# Altium schematic sheet Melanson Tech - PWM Generator.SchDoc
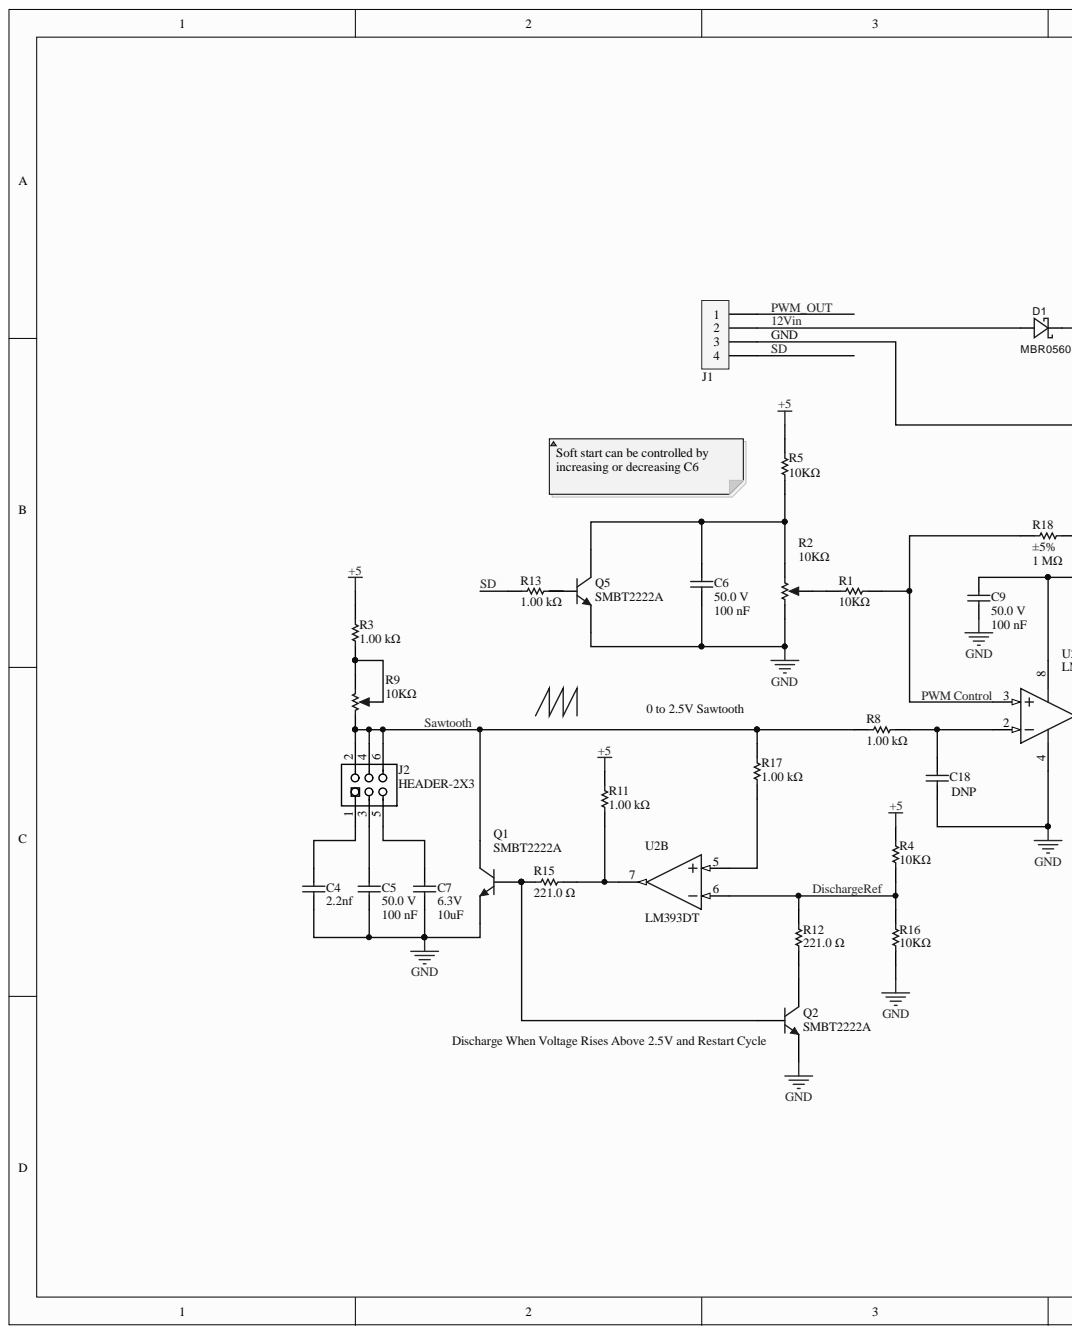
[diagram p0: Melanson Tech - PWM Generator.SchDoc, left]
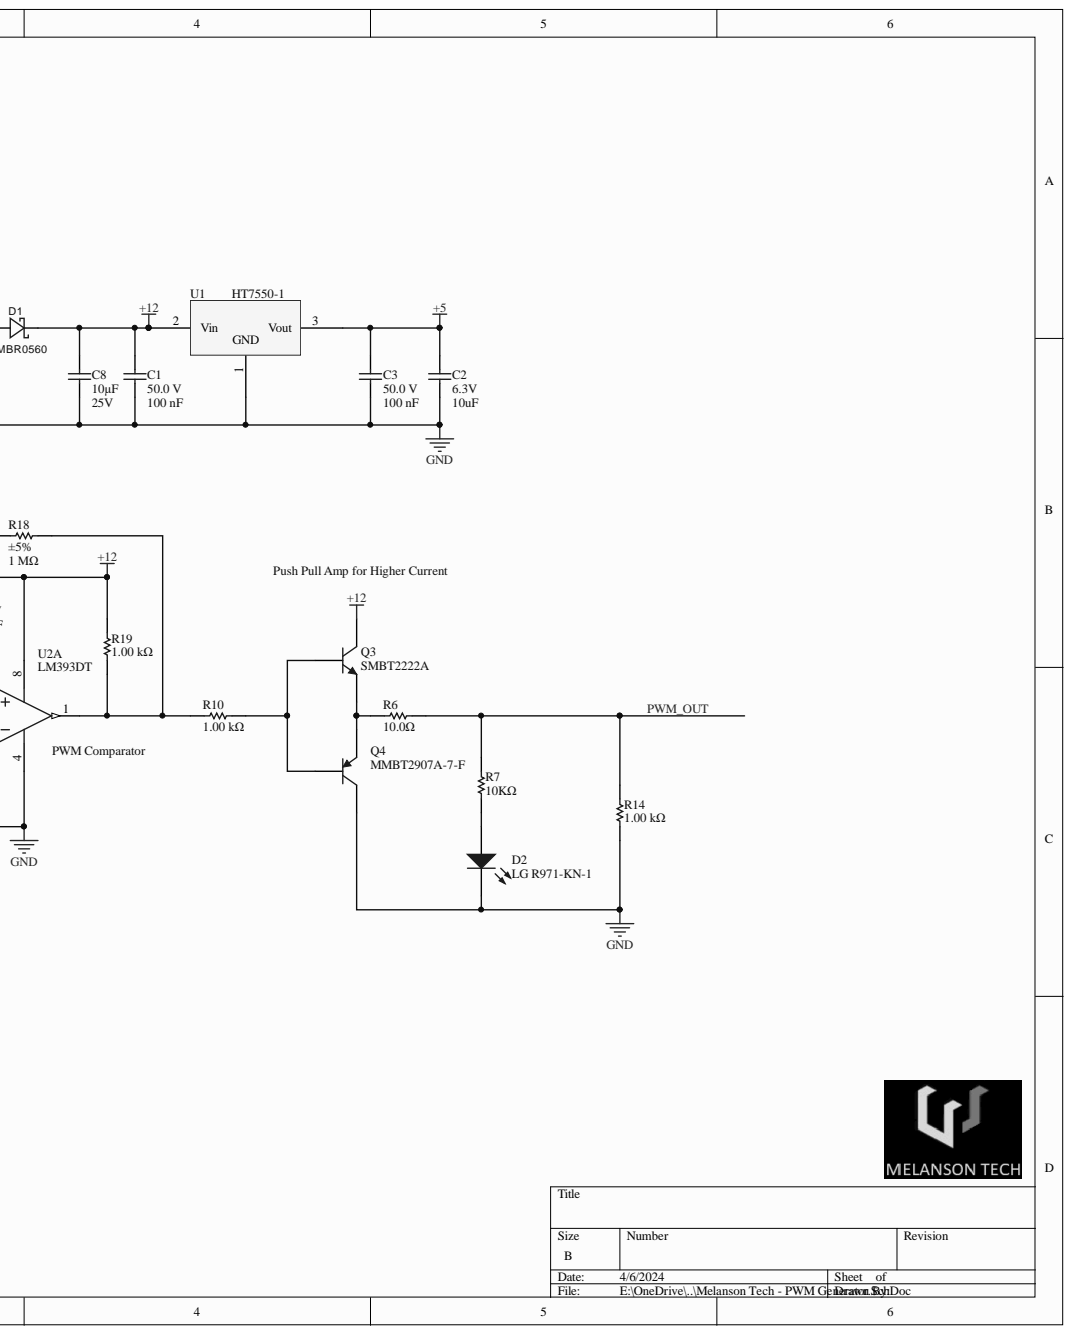
[diagram p1: Melanson Tech - PWM Generator.SchDoc, right]

## Parts
C1  08055C104JAT2A  [Cap,0805]  (value not drawn)
C2  Capacitance: 10uF  Voltage - Rated: 6.3V  [Cap,0805]  (hidden comment: CL21A106KQFNNNG)
C3  Voltage Rating (DC): 50.0 V  [Cap,0805]  (hidden comment: 08055C104JAT2A)
C4  2.2nf  [CAP, 0805, HD]
C5  08055C104JAT2A  [Cap,0805]  (value not drawn)
C6  Capacitance: 100 nF  Voltage Rating (DC): 50.0 V  [Cap,0805]  (hidden comment: 08055C104JAT2A)
C7  Capacitance: 10uF  Voltage - Rated: 6.3V  [Cap,0805]  (hidden comment: CL21A106KQFNNNG)
C8  Capacitance: 10µF  Voltage - Rated: 25V  [Cap, 1206]  (hidden comment: C3216X7R1E106K160AB)
C9  Capacitance: 100 nF  Voltage Rating (DC): 50.0 V  [Cap,0805]  (hidden comment: 08055C104JAT2A)
C18  Capacitance: DNP  [CAP, 0805, HD]  (hidden comment: GRM21A5C2E101JW01D)
D1  MBR0560  [SOD123]
D2  LG R971-KN-1  [LED,0805]
J1  Generic Header  [Header,4POS,0.1",Vert]  (value not drawn)
J2  HEADER-2X3  [HEADER-2X3]
Q1  SMBT2222A  [SOT23-3]
Q2  SMBT2222A  [SOT23-3]
Q3  SMBT2222A  [SOT23-3]
Q4  MMBT2907A-7-F  [SOT23-3]
Q5  SMBT2222A  [SOT23-3]
R1  Resistance: 10KΩ  [res, 0805, HD]  (hidden comment: Vishay / Dale CRCW080510K0FKTA)
R2  Resistance: 10KΩ  [Res,TH,POT,TopAdj]  (hidden comment: CT94EW103)
R3  Resistance: 1.00 kΩ  [res, 0805, HD]  (hidden comment: Vishay CRCW08051K00JNEA)
R4  Resistance: 10KΩ  [res, 0805, HD]  (hidden comment: Vishay / Dale CRCW080510K0FKTA)
R5  Resistance: 10KΩ  [res, 0805, HD]  (hidden comment: Vishay / Dale CRCW080510K0FKTA)
R6  Resistance: 10.0Ω  [res, 0805, HD]  (hidden comment: RMCF0805JT10R0)
R7  Resistance: 10KΩ  [res, 0805, HD]  (hidden comment: Vishay / Dale CRCW080510K0FKTA)
R8  Resistance: 1.00 kΩ  [res, 0805, HD]  (hidden comment: Vishay CRCW08051K00JNEA)
R9  Resistance: 10KΩ  [Res,TH,POT,TopAdj]  (hidden comment: CT94EW103)
R10  Resistance: 1.00 kΩ  [res, 0805, HD]  (hidden comment: Vishay CRCW08051K00JNEA)
R11  Resistance: 1.00 kΩ  [res, 0805, HD]  (hidden comment: Vishay CRCW08051K00JNEA)
R12  Vishay Dale CRCW0805221RFKTA  [res, 0805, HD]  (value not drawn)
R13  Resistance: 1.00 kΩ  [res, 0805, HD]  (hidden comment: Vishay CRCW08051K00JNEA)
R14  Resistance: 1.00 kΩ  [res, 0805, HD]  (hidden comment: Vishay CRCW08051K00JNEA)
R15  Resistance: 221.0 Ω  [res, 0805, HD]  (hidden comment: Vishay Dale CRCW0805221RFKTA)
R16  Resistance: 10KΩ  [res, 0805, HD]  (hidden comment: Vishay / Dale CRCW080510K0FKTA)
R17  Resistance: 1.00 kΩ  [res, 0805, HD]  (hidden comment: Vishay CRCW08051K00JNEA)
R18  Resistance: 1 MΩ  Tolerance: ±5%  [res, 0805, HD]  (hidden comment: CR0805-JW-105ELF)
R19  Resistance: 1.00 kΩ  [res, 0805, HD]  (hidden comment: Vishay CRCW08051K00JNEA)
U1  HT7550-1  [SOT89-3]
U2  LM393DT  [SOIC-8]  (parts A,B)

## Nets
+5: C2.2 C3.2 R3.2 R4.2 R5.2 R11.2 U1.3
+12: C1.2 C8.2 C9.2 D1.1 Q3.3 R19.1 U1.2 U2.8
GND: C1.1 C2.1 C3.1 C4.1 C5.1 C6.1 C7.1 C8.1 C9.1 C18.2 D2.2 J1.3 Q1.2 Q2.2 Q4.3 Q5.2 R2.3 R14.2 R16.1 U1.1 U2.4
12VIN: D1.2 J1.2
DISCHARGEREF: R4.1 R12.1 R16.2 U2.6
PWM CONTROL: R1.1 R18.2 U2.3
PWM_OUT: J1.1 R6.1 R7.2 R14.1
SAWTOOTH: J2.2 J2.4 J2.6 Q1.3 R8.1 R9.1 R17.2
SD: J1.4 R13.1
NetC4_2: C4.2 J2.1
NetC5_2: C5.2 J2.3
NetC6_2: C6.2 Q5.3 R2.1 R5.1
NetC7_2: C7.2 J2.5
NetC18_1: C18.1 R8.2 U2.2
NetD2_1: D2.1 R7.1
NetQ1_1: Q1.1 Q2.1 R15.2
NetQ2_3: Q2.3 R12.2
NetQ3_1: Q3.1 Q4.1 R10.2
NetQ3_2: Q3.2 Q4.2 R6.2
NetQ5_1: Q5.1 R13.2
NetR1_2: R1.2 R2.2
NetR3_1: R3.1 R9.2 R9.3
NetR10_1: R10.1 R18.1 R19.2 U2.1
NetR11_1: R11.1 R15.1 U2.7
NetR17_1: R17.1 U2.5

## Library data of the parts
C1 C5 = Cap,0805,100nf,50V,5%,08055C104JAT2A: Capacitor; Ceramic; Cap 0.1 uF; Tol 5%; Vol-Rtg 50 VDC; SMT; 0805; X7R; Tape & Reel; Capacitance: 100 nF; Case/Package (SI): 2012; Detailed Description: 0.1µF ±5% 50V Ceramic Capacitor X7R 0805 (2012 Metric); Insulation Resistance: 100 GΩ; Lead-Free Status: Lead Free; Voltage Rating (DC): 50.0 V; Capacitance Tolerance: ±5%; Case/Package: 0805; Component Kind: Standard; Dielectric Characteristic: X7R; digikey url: http://www.digikey.ca/product-detail/en/avx-corporation/08055C104JAT2A/478-3352-1-ND/930144; Library Name: Master JULY2019.SchLib; Manufacturer Part Number: 08055C104JAT2A; Mounting Style: Surface Mount; Number of Pins: 2; Octopart URL: http://octopart.com/08055c104jat2a-avx-55388010; Operating Temperature: -55°C ~ 125°C; Packaging: Tape & Reel (TR), Cut Tape (CT); REACH SVHC Compliance: No SVHC; RoHS: Compliant; Size-Height: 710 µm; Size-Length: 2.01 mm; Size-Thickness: 940 µm; Size-Width: 1.24 mm; Supplier 1: Digi-Key; Supplier Part Number 1: 478-3352-1-ND
C2 C7 = Cap,0805,10uf,6.3V,10%,CL21A106KQFNNNG: CL21 Series 10 uF 6.3V ±10 % Tolerance X5R SMT Multilayer Ceramic Capacitor; Lead-Free Status: Lead Free; Other Names: 1276-6457-2; Tolerance: ±10%; Applications: General Purpose; Component Kind: Standard; Library Name: Master JULY2019.SchLib; mfg P/N: CL21A106KQFNNNG; Mounting Style: Surface Mount; Operating Temperature: -55°C ~ 85°C; Package / Case: 0805 (2012 Metric); RoHS: Compliant; Supplier 1: Digi-Key; Supplier Part Number 1: 1276-6457-1-ND
C3 = Cap,0805,100nf,50V,5%,08055C104JAT2A: Capacitor; Ceramic; Cap 0.1 uF; Tol 5%; Vol-Rtg 50 VDC; SMT; 0805; X7R; Tape & Reel; Capacitance: 100 nF; Case/Package (SI): 2012; Detailed Description: 0.1µF ±5% 50V Ceramic Capacitor X7R 0805 (2012 Metric); Insulation Resistance: 100 GΩ; Lead-Free Status: Lead Free; Capacitance Tolerance: ±5%; Case/Package: 0805; Component Kind: Standard; Dielectric Characteristic: X7R; digikey url: http://www.digikey.ca/product-detail/en/avx-corporation/08055C104JAT2A/478-3352-1-ND/930144; Library Name: Master JULY2019.SchLib; Manufacturer Part Number: 08055C104JAT2A; Mounting Style: Surface Mount; Number of Pins: 2; Octopart URL: http://octopart.com/08055c104jat2a-avx-55388010; Operating Temperature: -55°C ~ 125°C; Packaging: Tape & Reel (TR), Cut Tape (CT); REACH SVHC Compliance: No SVHC; RoHS: Compliant; Size-Height: 710 µm; Size-Length: 2.01 mm; Size-Thickness: 940 µm; Size-Width: 1.24 mm; Supplier 1: Digi-Key; Supplier Part Number 1: 478-3352-1-ND
C4 = CAP,0805,Generic: Component Kind: Standard; Library Name: Master Nov2021.SchLib
C6 C9 = Cap,0805,100nf,50V,5%,08055C104JAT2A: Capacitor; Ceramic; Cap 0.1 uF; Tol 5%; Vol-Rtg 50 VDC; SMT; 0805; X7R; Tape & Reel; Case/Package (SI): 2012; Detailed Description: 0.1µF ±5% 50V Ceramic Capacitor X7R 0805 (2012 Metric); Insulation Resistance: 100 GΩ; Lead-Free Status: Lead Free; Capacitance Tolerance: ±5%; Case/Package: 0805; Component Kind: Standard; Dielectric Characteristic: X7R; digikey url: http://www.digikey.ca/product-detail/en/avx-corporation/08055C104JAT2A/478-3352-1-ND/930144; Library Name: Master JULY2019.SchLib; Manufacturer Part Number: 08055C104JAT2A; Mounting Style: Surface Mount; Number of Pins: 2; Octopart URL: http://octopart.com/08055c104jat2a-avx-55388010; Operating Temperature: -55°C ~ 125°C; Packaging: Tape & Reel (TR), Cut Tape (CT); REACH SVHC Compliance: No SVHC; RoHS: Compliant; Size-Height: 710 µm; Size-Length: 2.01 mm; Size-Thickness: 940 µm; Size-Width: 1.24 mm; Supplier 1: Digi-Key; Supplier Part Number 1: 478-3352-1-ND
C8 = CAP,1206,10uF,25V,C3216X7R1E106K160AB: 10µF ±10% 25V Ceramic Capacitor X7R 1206 (3216 Metric); Manufacturer: TDK Corporation; Features Low: ESL; Applications: General Purpose; Component Kind: Standard; mfg P/N: C3216X7R1E106K160AB; Mounting Type: Surface Mount, MLCC; Operating Temperature: -55°C ~ 125°C; Package / Case: 1206 (3216 Metric); Size / Dimension: 0.126" L x 0.063" W (3.20mm x 1.60mm); Supplier 1: Digi-Key; Supplier Part Number 1: 445-7705-1-ND; Temperature Coefficient: X7R; Thickness (Max): 0.075" (1.90mm); Tolerance: ±10%; Library Name: Master DEC 2020.SchLib
C18 = Cap,0805,100pf,250V,5%,GRM21A5C2E101JW01D: CAP CER 100PF 250V 5% NP0 0805; Dielectric Characteristic: C0G/NP0; Lead-Free Status: Lead Free; Voltage Rating (DC): 250.0 V; Capacitance Tolerance: ±5%; Case/Package: 0805; Component Kind: Standard; Library Name: Master JULY2019.SchLib; mfg P/N: GRM21A5C2E101JW01D; RoHS: Compliant; Size-Length: 0.002 m; Size-Thickness: 0.001 m; Size-Width: 0.00125 m; Supplier 1: Digi-Key; Supplier 2: LCSC; Supplier Part Number 1: 490-5542-1-ND; Supplier Part Number 2: C162304
D1 = Diode,SOD123,Shottky,60V,0.5A,MBR0560: Dio,Sig,Schtky,0.5A,60V,SOD123; Manufacturer: MCC; Details: 700mV @ 500mA, 200µA @ 60V,30pF @ 4V, 1MHz; mfg P/N: MBR0560-TP; Not Stuffed: N/S; Component Kind: Standard; Current: 0.5A; Fast Supplier: Digi-Key; Package: SOD123; Supplier 1: Digi-Key; Supplier 2: LCSC; Supplier Part Number 1: MBR0560TPMSCT-ND; Supplier Part Number 2: C150515; Voltage: 60V; Library Name: Master Mar2021.SchLib
D2 = LED,0805, Green,SMD,LG R971-KN-1: LED GREEN DIFFUSED 0805 SMD; Categories: Optoelectronics   LED Indication - Discrete; Configuration: Standard; Current - Test: 20mA; Forward Voltage: 2.2V; Lead-Free Status: Lead Free; Lens Color: White; Lens Size: 1.30mm x 1.25mm; Lens Style: Rectangle with Flat Top; mfg P/N: LTST-C930KRKT; Millicandela Rating: 26.05mcd; Viewing Angle: 160°; Wavelength - Dominant: 570nm; Wavelength - Peak: 572nm; Color: Green; Component Kind: Standard; Height (Max): 0.90mm; Lens Type: Diffused; Mounting Style: Surface Mount; Package / Case: 0805 (2012 Metric); RoHS: Compliant; Size / Dimension: 2.00mm L x 1.25mm W; Supplier 1: Digi-Key; Supplier Device Package: 0805; Supplier Part Number 1: 475-1410-1-ND; Library Name: Master DEC 2020.SchLib
J1 = Header 1x4,0.1",TH,Generic: Generic Header 4 pos header 0.1"; Component Kind: Standard; Library Name: Master Nov2021.SchLib
Q1-Q3 Q5 = Trans,40V,600mA,0.33W, SMBT2222A: NPN Silicon Switching Transistor, 40 V VCEO, 600 mA IC, SOT23, Reel, Green; Current - Collector Cutoff (Max): 10nA (ICBO); DC Current Gain (hFE) (Min) @ Ic, Vce: 100 @ 150mA, 10V; Frequency - Transition: 300MHz; Power Dissipation: 0.33 W; Vce Saturation (Max) @ Ib, Ic: 1V @ 50mA, 500mA; Voltage - Collector Emitter Breakdown (Max): 40V; Component Kind: Standard; Library Name: Master JULY2019.SchLib; mfg P/N: SMBT2222A; Mounting Style: Surface Mount; Mounting Type: Surface Mount; Number of Pins: 3; Package / Case: TO-236-3, SC-59, SOT-23-3; Packaging: Cut Tape (CT); Polarity: NPN; REACH SVHC Compliance: No SVHC; RoHS: Compliant; Supplier 1: Digi-Key; Supplier 2: Digi-Key; Supplier 3: Digi-Key; Supplier 4: LCSC; Supplier Device Package: SOT-23-3; Supplier Part Number 1: SMBT2222AE6327HTSA1CT-ND; Supplier Part Number 2: MMBT2222ALT1HTSA1CT-ND; Supplier Part Number 3: SMBT2222AE6327HTSA1DKR-ND; Supplier Part Number 4: C151510
Q4 = Trans,PNP,60Vdc,600mA,SOT-23-3,MMBT2907A-7-F: TRANS PNP 60V 0.6A SOT23-3; Current - Collector (Ic) (Max): 600mA; Current - Collector Cutoff (Max): 10nA (ICBO); DC Current Gain (hFE) (Min) @ Ic, Vce: 100 @ 150mA, 10V; Detailed Description: Bipolar (BJT) Transistor PNP 60V 600mA 200MHz 300mW Surface Mount SOT-23-3; Frequency - Transition: 200MHz; Power - Max: 300mW; Vce Saturation (Max) @ Ib, Ic: 1.6V @ 50mA, 500mA; Component Kind: Standard; Library Name: Master JULY2019.SchLib; mfg P/N: MMBT2907A-7-F; Mounting Type: Surface Mount; Operating Temperature: -55°C ~ 150°C (TJ); Package / Case: TO-236-3, SC-59, SOT-23-3; Supplier 1: Digi-Key; Supplier 2: LCSC; Supplier Device Package: SOT-23-3; Supplier Part Number 1: MMBT2907A-FDICT-ND; Supplier Part Number 2: C106923; Transistor Type: PNP; Voltage - Collector Emitter Breakdown (Max): 60V
R1 R4 R5 R7 R16 = Res,0805,10.0kΩ,1%,100 ppm,CRCW080510K0FKTA: RES SMD 10K OHM 1% 1/8W 0805; Case/Package (SI): 2012; Lead-Free Status: Contains Lead; Power Dissipation: 0.125 W; Temperature Coefficient: 100.0 ppm/C; Voltage Rating (DC): 150.0 V; Case/Package: 0805; Component Kind: Standard; Library Name: Master JULY2019.SchLib; Mounting Style: Surface Mount; Number of Pins: 2; Power Rating: 0.125 W; REACH SVHC Compliance: No SVHC; Resistance Tolerance: 1%; RoHS: Non-Compliant; Size-Height: 0.00045 m; Size-Length: 0.002 m; Size-Width: 0.00125 m; Supplier 1: Digi-Key; Supplier Part Number 1: 541-3016-1-ND
R2 R9 = Res,POT,TH,10KΩ,0.5W,±10%,±100ppm/°C,CT94EW103: TRIMMER 10K OHM 0.5W PC PIN TOP; Manufacturer: Nidec Copal Electronics; Adjustment Type: Top Adjustment; Detailed Description: 10 kOhms 0.5W, 1/2W PC Pins Through Hole Trimmer Potentiometer Cermet 18 Turn Top Adjustment; Number of Turns: 18; Power (Watts): 0.5W, 1/2W; Resistive Material: Cermet; Tolerance: ±10%; Category: Potentiometers, Variable Resistors; Component Kind: Standard; mfg P/N: CT94EW103; Mounting Type: Through Hole; Part Status: Active; Series: CT94; Size / Dimension: Square - 0.378"" L x 0.189"" W x 0.378"" H (9.60mm x 4.80mm x 9.60mm); Supplier 1: Digi-Key; Supplier Part Number 1: CT94EW103-ND; Temperature Coefficient: ±100ppm/°C; Termination Style: PC Pins; Library Name: Master Mar2021.SchLib
R3 R8 R10 R11 R13 R14 R17 R19 = Res,0805,1.00 kΩ,5%,200ppm,CRCW08051K00JNEA: Res Thick Film 0805 1K Ohm 5% 0.125W(1/8W) ±200ppm/C Molded SMD Automotive Paper T/R; Case/Package (SI): 2012; Lead-Free Status: Lead Free; Power Rating: 125 mW; Temperature Coefficient: 200 ppm/°C; Voltage Rating (DC): 150 V; Case/Package: 0805; Component Kind: Standard; Library Name: Master JULY2019.SchLib; Manufacturer Part Number: CRCW08051K00JNEA; Mounting Style: Surface Mount; Number of Pins: 2; Packaging: Tape & Reel (TR), Cut Tape (CT); REACH SVHC Compliance: No SVHC; Resistance Tolerance: ±5%; RoHS: Compliant; Size-Height: 450 µm; Size-Length: 2.00 mm; Size-Width: 1.25 mm; Supplier 1: Digi-Key; Supplier 2: Digi-Key; Supplier Part Number 1: 541-1.0KADKR-ND; Supplier Part Number 2: 541-1.0KACT-ND
R6 = Res,0805,10.0Ω,5%,±200ppm/°C,RMCF0805JT10R0: RES 10 OHM 5% 1/8W 0805; Manufacturer: Stackpole Electronics Inc; Categories: Resistors   Chip Resistor - Surface Mount; Detailed Description: 10 Ohms ±5% 0.125W, 1/8W Chip Resistor 0805 (2012 Metric) Automotive AEC-Q200 Thick Film; Power (Watts): 0.125W, 1/8W; Component Kind: Standard; Composition: Thick Film; Features: Automotive AEC-Q200; Library Name: Master JULY2019.SchLib; mfg P/N: RMCF0805JT10R0; Operating Temperature: -55°C ~ 155°C; Package / Case: 0805 (2012 Metric); Part Status: Active; Ratings: AEC-Q200; Series: RMCF; Supplier 1: Digi-Key; Supplier Device Package: 0805; Supplier Part Number 1: RMCF0805JT10R0CT-ND; Temperature Coefficient: ±200ppm/°C; Tolerance: ±5%
R12 = Res,0805,221Ω,1%,100ppm,CRCW0805221RFKTA: RES SMD 221 OHM 1% 1/8W 0805; Case/Package (SI): 2012; Lead-Free Status: Contains Lead; Power Dissipation: 0.125 W; Resistance: 221.0 Ω; Temperature Coefficient: 100.0 ppm/°C; Voltage Rating (DC): 150.0 V; Case/Package: 0805; Component Kind: Standard; Mounting Style: Surface Mount; Number of Pins: 2; Power Rating: 0.125 W; Resistance Tolerance: ±1%; RoHS: Non-Compliant; Size-Height: 0.00045 m; Size-Length: 0.002 m; Size-Width: 0.00125 m; Supplier 1: Digi-Key; Supplier Part Number 1: 541-221CCT-ND; Library Name: Master Mar2021.SchLib
R15 = Res,0805,221Ω,1%,100ppm,CRCW0805221RFKTA: RES SMD 221 OHM 1% 1/8W 0805; Case/Package (SI): 2012; Lead-Free Status: Contains Lead; Power Dissipation: 0.125 W; Temperature Coefficient: 100.0 ppm/°C; Voltage Rating (DC): 150.0 V; Case/Package: 0805; Component Kind: Standard; Mounting Style: Surface Mount; Number of Pins: 2; Power Rating: 0.125 W; Resistance Tolerance: ±1%; RoHS: Non-Compliant; Size-Height: 0.00045 m; Size-Length: 0.002 m; Size-Width: 0.00125 m; Supplier 1: Digi-Key; Supplier Part Number 1: 541-221CCT-ND; Library Name: Master Mar2021.SchLib
R18 = Res,0805,1MegΩ,5%,200ppm,0805W8F1005T5E: RES SMD 1M OHM 5% 1/8W 0805; Manufacturer: Bourns Inc.; Detailed Description: 1 MOhms ±5% 0.125W, 1/8W Chip Resistor 0805 (2012 Metric) Moisture Resistant Thick Film; Power (Watts): 0.125W, 1/8W; Temperature Coefficient: ±200ppm/°C; Category: Resistors  Chip Resistor - Surface Mount; Component Kind: Standard; Composition: Thick Film; Features: Moisture Resistant; Height - Seated (Max): 0.024"" (0.60mm); JLC ALt LCSC Part #: C26108; Library Name: Master JULY2019.SchLib; mfg P/N: CR0805-JW-105ELF; Operating Temperature: -55°C ~ 155°C; Package / Case: 0805 (2012 Metric); Part Status: Active; Series: CR0805; Size / Dimension: 0.079"" L x 0.049"" W (2.00mm x 1.25mm); Supplier 1: Digi-Key; Supplier Device Package: 0805; Supplier Part Number 1: CR0805-JW-105ELFCT-ND
U1 = Reg,Linear,Fixed,SOT-89-3,30Vin,5Vout,150mA,HT7550-1: LOW DROPOUT REGULATORS(LDO) POSITIVE FIXED 55MV @ 1MA 30V 5V 150MA SOT-89(SOT-89-3) ROHS; Manufacturer: Holtek Semicon; Input Voltage (Max): 30Vdc; Output Voltage: 5Vdc; Output voltage accuracy: tolerance ±3%; Quiescent current: 2.5μA; Component Kind: Standard; LCSC Part #: C16106; mfg P/N: HT7550-1; Output Current (Max): 150mA; Package: SOT-89-3; Library Name: Master DEC 2020.SchLib
U2 = OPAMP,DUAL,COMAPRATOR,SOIC8,OPEN-COLLECTOR,LM393DT: IC COMPARATOR LP DUAL 8-SOIC; Manufacturer: STMicroelectronics; Current - Input Bias (Max): 0.25µA @ 5V; Current - Output (Typ): 18mA @ 5V; Current - Quiescent (Max): 2.5mA; Number of Elements: 2; Output Type: CMOS, DTL, ECL, MOS, Open-Collector, TTL; Package / Case 8-SOIC: (0.154", 3.90mm Width); Voltage - Input Offset (Max): 5mV @ 30V; Voltage - Supply, Single/Dual (±): 2V ~ 36V, ±1V ~ 18V; Base Part Number: LM393; Component Kind: Standard; Library Name: Master JULY2019.SchLib; mfg P/N: LM393DT; Mounting Type: Surface Mount; Operating Temperature: 0°C ~ 70°C; Part Status: Active; Supplier 1: Digi-Key; Supplier 2: LCSC; Supplier Device Package: 8-SO; Supplier Part Number 1: 497-1593-1-ND; Supplier Part Number 2: C32901; Type: General Purpose
J2 = HEADER-2X3: Component Kind: Standard; Library Name: TH Connectors.SchLib
